# Altium schematic sheet hellen1-mega-mcu64.SchDoc(hellen1-mega-mcu64)
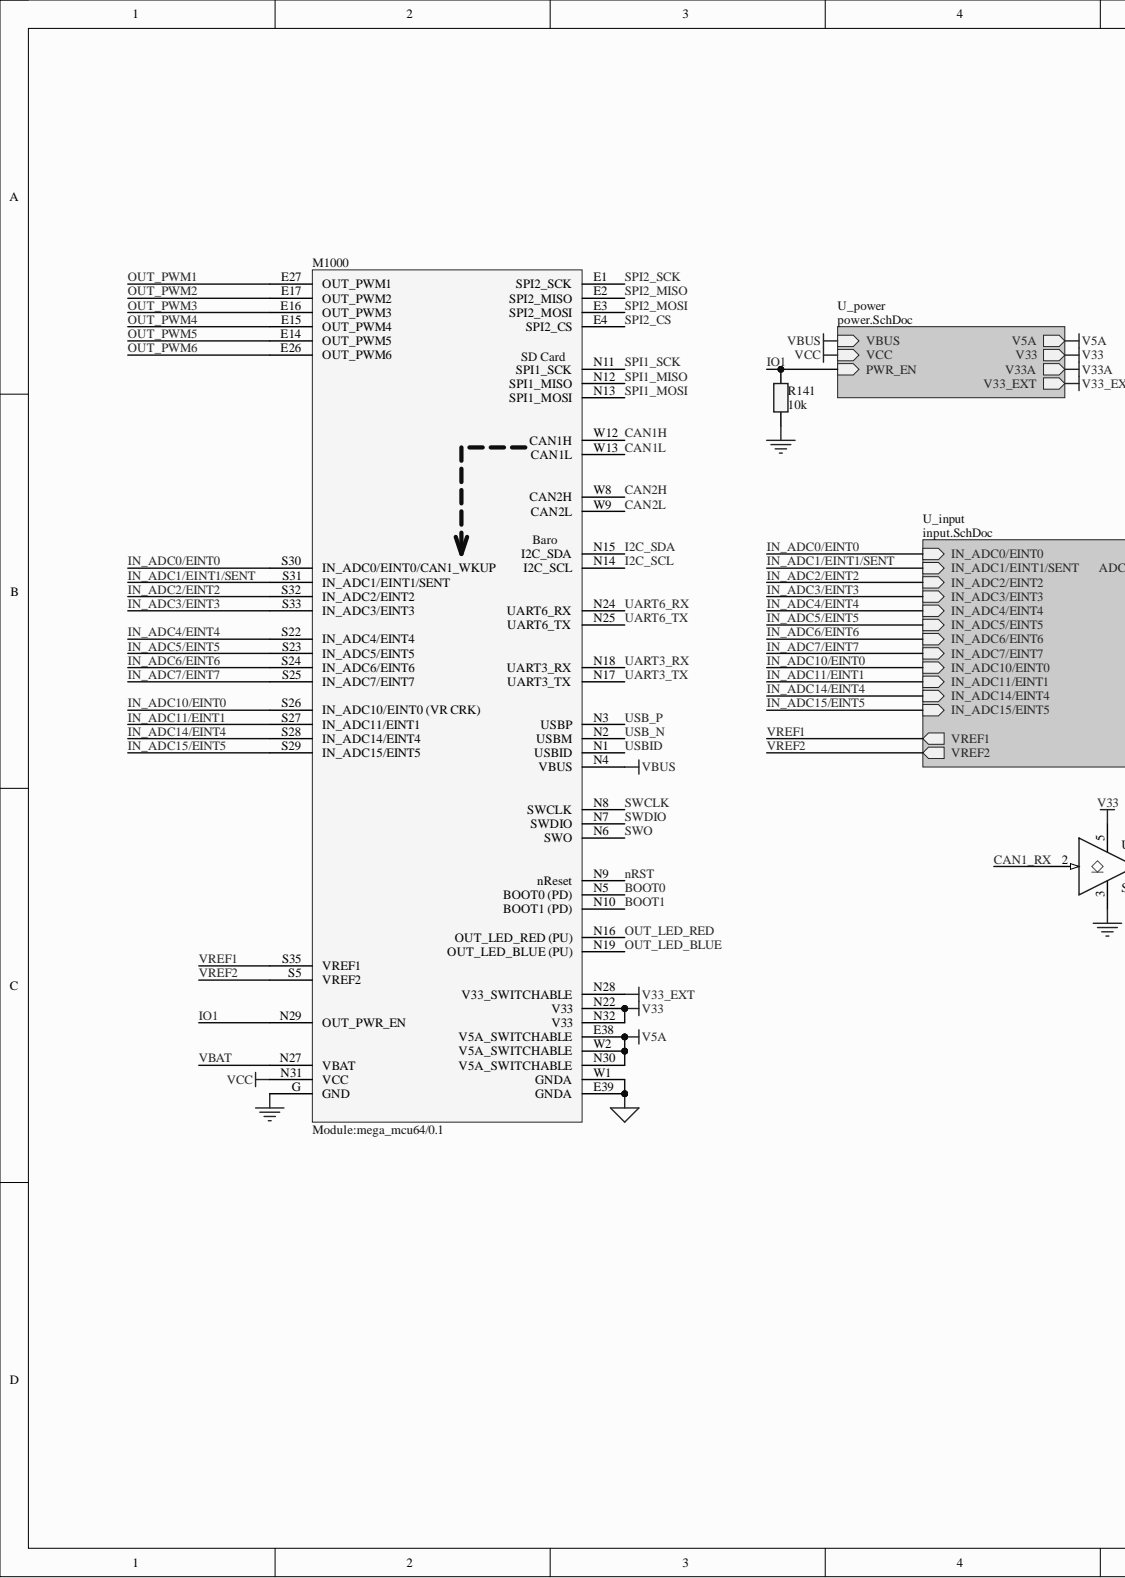
[diagram p0: hellen1-mega-mcu64.SchDoc, left]
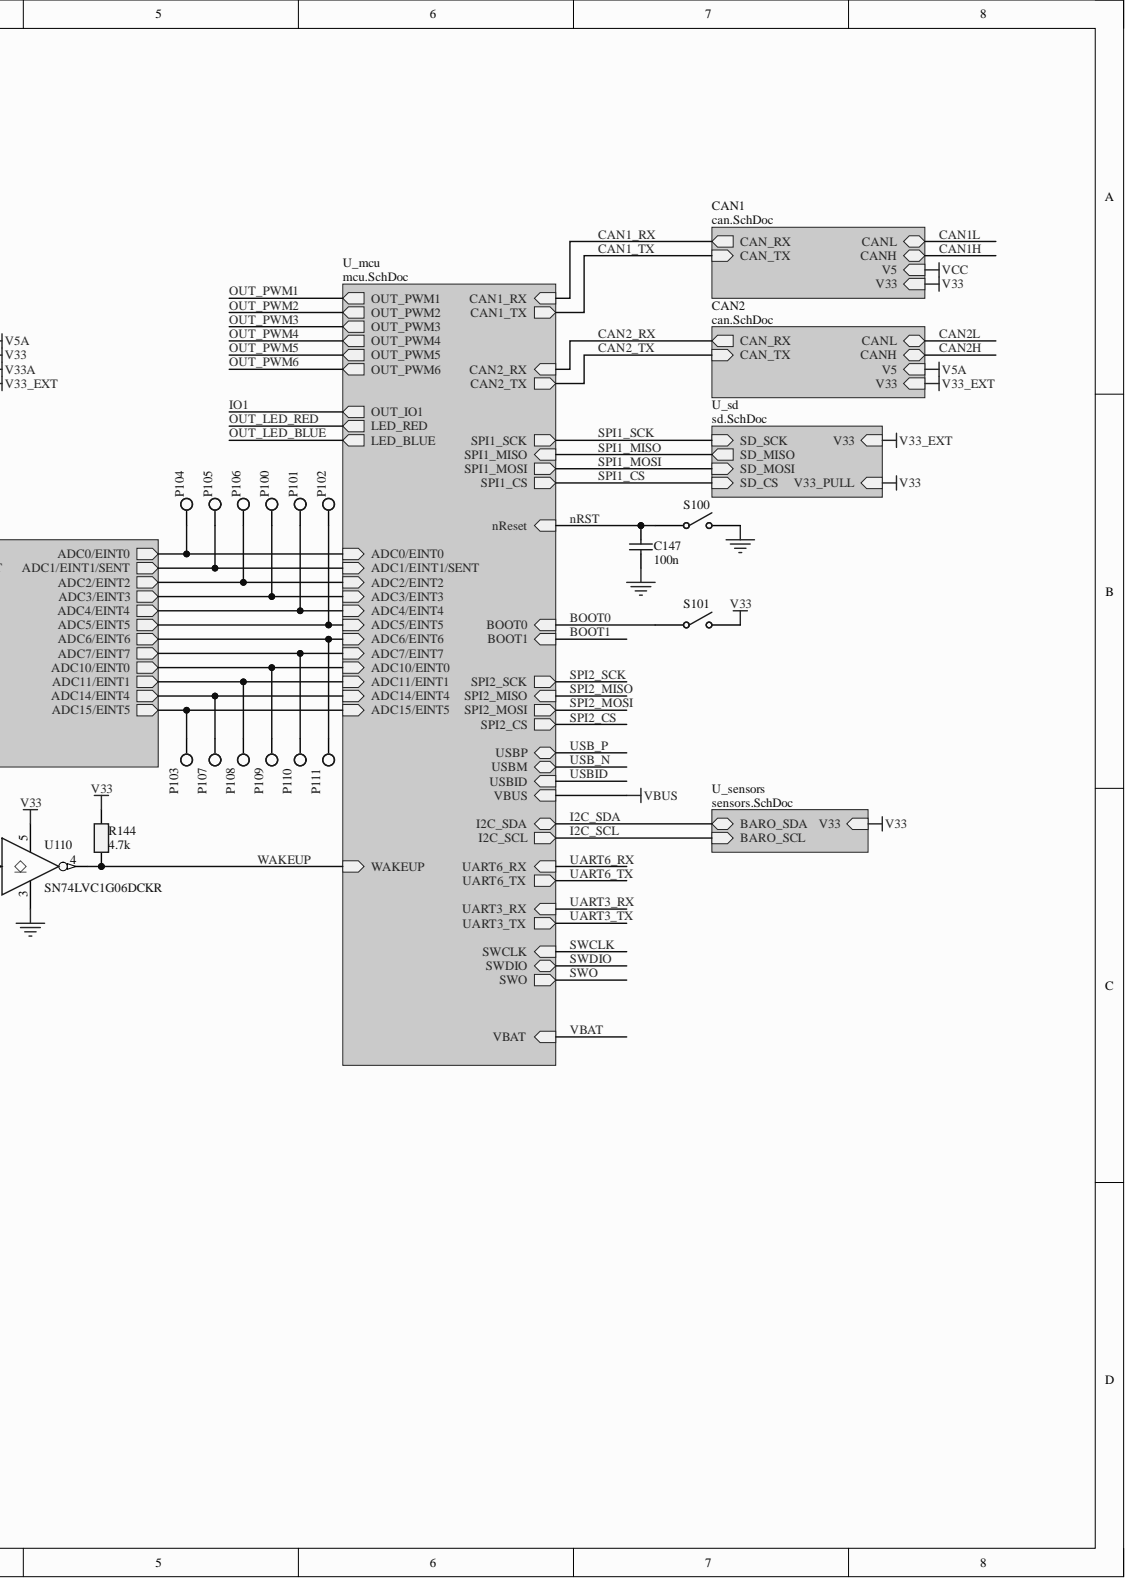
[diagram p1: hellen1-mega-mcu64.SchDoc, right]

## Parts
C147  100n  [C0402HD]
M1000  Module:mega_mcu64/0.1  [MOD_Hellen_MEGA_MCU144_0.2]
P100  ADC3  [HDR1X1]  (value not drawn)  (no BOM)
P101  ADC4  [HDR1X1]  (value not drawn)  (no BOM)
P102  ADC5  [HDR1X1]  (value not drawn)  (no BOM)
P103  ADC15  [HDR1X1]  (value not drawn)  (no BOM)
P104  ADC0  [HDR1X1]  (value not drawn)  (no BOM)
P105  ADC1  [HDR1X1]  (value not drawn)  (no BOM)
P106  ADC2  [HDR1X1]  (value not drawn)  (no BOM)
P107  ADC14  [HDR1X1]  (value not drawn)  (no BOM)
P108  ADC11  [HDR1X1]  (value not drawn)  (no BOM)
P109  ADC10  [HDR1X1]  (value not drawn)  (no BOM)
P110  ADC7  [HDR1X1]  (value not drawn)  (no BOM)
P111  ADC6  [HDR1X1]  (value not drawn)  (no BOM)
R141  10k  [R0402]
R144  4.7k  [R0402]
S100  BTN  [BUTTON-SKRK]  (value not drawn)
S101  BTN  [BUTTON-SKRK]  (value not drawn)
U110  SN74LVC1G06DCKR  [SOT-353]

## Nets
GND: C147.1 M1000.G(GND) R141.1 S100.2 U110.3
GNDA: M1000.E39(GNDA) M1000.W1(GNDA)
V5A: M1000.E38(V5A_SWITCHABLE) M1000.N30(V5A_SWITCHABLE) M1000.W2(V5A_SWITCHABLE)
V33: M1000.N22(V33) M1000.N32(V33) R144.1 S101.2 U110.5
V33_EXT: M1000.N28(V33_SWITCHABLE)
VBUS: M1000.N4(VBUS)
VCC: M1000.N31(VCC)
BOOT0: M1000.N5(BOOT0_(PD)) S101.1
BOOT1: M1000.N10(BOOT1_(PD))
CAN1_RX: U110.2
CAN1H: M1000.W12(CAN1H)
CAN1L: M1000.W13(CAN1L)
CAN2H: M1000.W8(CAN2H)
CAN2L: M1000.W9(CAN2L)
I2C_SCL: M1000.N14(I2C_SCL)
I2C_SDA: M1000.N15(I2C_SDA)
IN_ADC0/EINT0: M1000.S30(IN_ADC0/EINT0/CAN1_WKUP)
IN_ADC1/EINT1/SENT: M1000.S31(IN_ADC1/EINT1/SENT)
IN_ADC2/EINT2: M1000.S32(IN_ADC2/EINT2)
IN_ADC3/EINT3: M1000.S33(IN_ADC3/EINT3)
IN_ADC4/EINT4: M1000.S22(IN_ADC4/EINT4)
IN_ADC5/EINT5: M1000.S23(IN_ADC5/EINT5)
IN_ADC6/EINT6: M1000.S24(IN_ADC6/EINT6)
IN_ADC7/EINT7: M1000.S25(IN_ADC7/EINT7)
IN_ADC10/EINT0: M1000.S26(IN_ADC10/EINT0_(VR_CRK))
IN_ADC11/EINT1: M1000.S27(IN_ADC11/EINT1)
IN_ADC14/EINT4: M1000.S28(IN_ADC14/EINT4)
IN_ADC15/EINT5: M1000.S29(IN_ADC15/EINT5)
IO1: M1000.N29(OUT_PWR_EN) R141.2
nRST: C147.2 M1000.N9(nReset) S100.1
OUT_LED_BLUE: M1000.N19(OUT_LED_BLUE_(PU))
OUT_LED_RED: M1000.N16(OUT_LED_RED_(PU))
OUT_PWM1: M1000.E27(OUT_PWM1)
OUT_PWM2: M1000.E17(OUT_PWM2)
OUT_PWM3: M1000.E16(OUT_PWM3)
OUT_PWM4: M1000.E15(OUT_PWM4)
OUT_PWM5: M1000.E14(OUT_PWM5)
OUT_PWM6: M1000.E26(OUT_PWM6)
SPI1_MISO: M1000.N12(SPI1_MISO)
SPI1_MOSI: M1000.N13(SPI1_MOSI)
SPI1_SCK: M1000.N11(SPI1_SCK)
SPI2_CS: M1000.E4(SPI2_CS)
SPI2_MISO: M1000.E2(SPI2_MISO)
SPI2_MOSI: M1000.E3(SPI2_MOSI)
SPI2_SCK: M1000.E1(SPI2_SCK)
SWCLK: M1000.N8(SWCLK)
SWDIO: M1000.N7(SWDIO)
SWO: M1000.N6(SWO)
UART3_RX: M1000.N18(UART3_RX)
UART3_TX: M1000.N17(UART3_TX)
UART6_RX: M1000.N24(UART6_RX)
UART6_TX: M1000.N25(UART6_TX)
USB_N: M1000.N2(USBM)
USB_P: M1000.N3(USBP)
USBID: M1000.N1(USBID)
VBAT: M1000.N27(VBAT)
VREF1: M1000.S35(VREF1)
VREF2: M1000.S5(VREF2)
WAKEUP: R144.2 U110.4
NetP100_1: P100.1
NetP101_1: P101.1
NetP102_1: P102.1
NetP103_1: P103.1
NetP104_1: P104.1
NetP105_1: P105.1
NetP106_1: P106.1
NetP107_1: P107.1
NetP108_1: P108.1
NetP109_1: P109.1
NetP110_1: P110.1
NetP111_1: P111.1
CAN1_TX:
CAN2_RX:
CAN2_TX:
SPI1_CS:
V33A:

## Library data of the parts
C147 = Cap: Capacitor; Fitted: True; Type: SMD; Component Kind: Standard; Library Name: General.schlib; PackageReference: C0402; Signal Integrity: Capacitor; Supplier 3: LCSC; Supplier Part Number 3: C1525
M1000 = Mod-Hellen-MEGA_MCU64_0.1: Hellen One MEGA_MCU64 Module; Fitted: False; Component Kind: Standard; Library Name: Mod.SchLib; Supplier 1: Mouser; Supplier 2: Digi-Key; Supplier 3: LCSC; Type: Module; Publisher: qwerty-off
P100-P111 = Pad: Fitted: False; Description: Pad; Library Name: General.schlib
R141 R144 = Res: Resistor; Fitted: True; Component Kind: Standard; Library Name: General.schlib; PackageReference: R0402; PCB3D: 0402; Signal Integrity: Resistor; Supplier 3: LCSC; Type: SMD
  R141: Supplier Part Number 3: C25744
  R144: Supplier 1: Mouser; Supplier 2: DigiKey; Supplier Part Number 3: C25900
S100 S101 = Switch SPST: Single-Pole, Single-Throw Switch; Fitted: True; Component Kind: Standard; LatestRevisionDate: 17-Jul-2002; LatestRevisionNote: Re-released for DXP Platform.; Library Name: General.schlib; PackageReference: SMD-2_2.9x3.9x1.7; Published: 24-Mar-1999; Supplier 3: LCSC; Supplier Part Number 3: C115357; Type: SMD; Publisher: Altium Limited
U110 = 74LVC1G06: Component Kind: Standard; Library Name: IC.SchLib; PackageReference: SOT-353; Supplier 1: Mouser; Supplier 2: DigiKey; Supplier 3: LCSC; Supplier Part Number 3: C7828; Type: SMD
